# Altium schematic sheet DynamicComplexModulo_v1i1.SchDoc
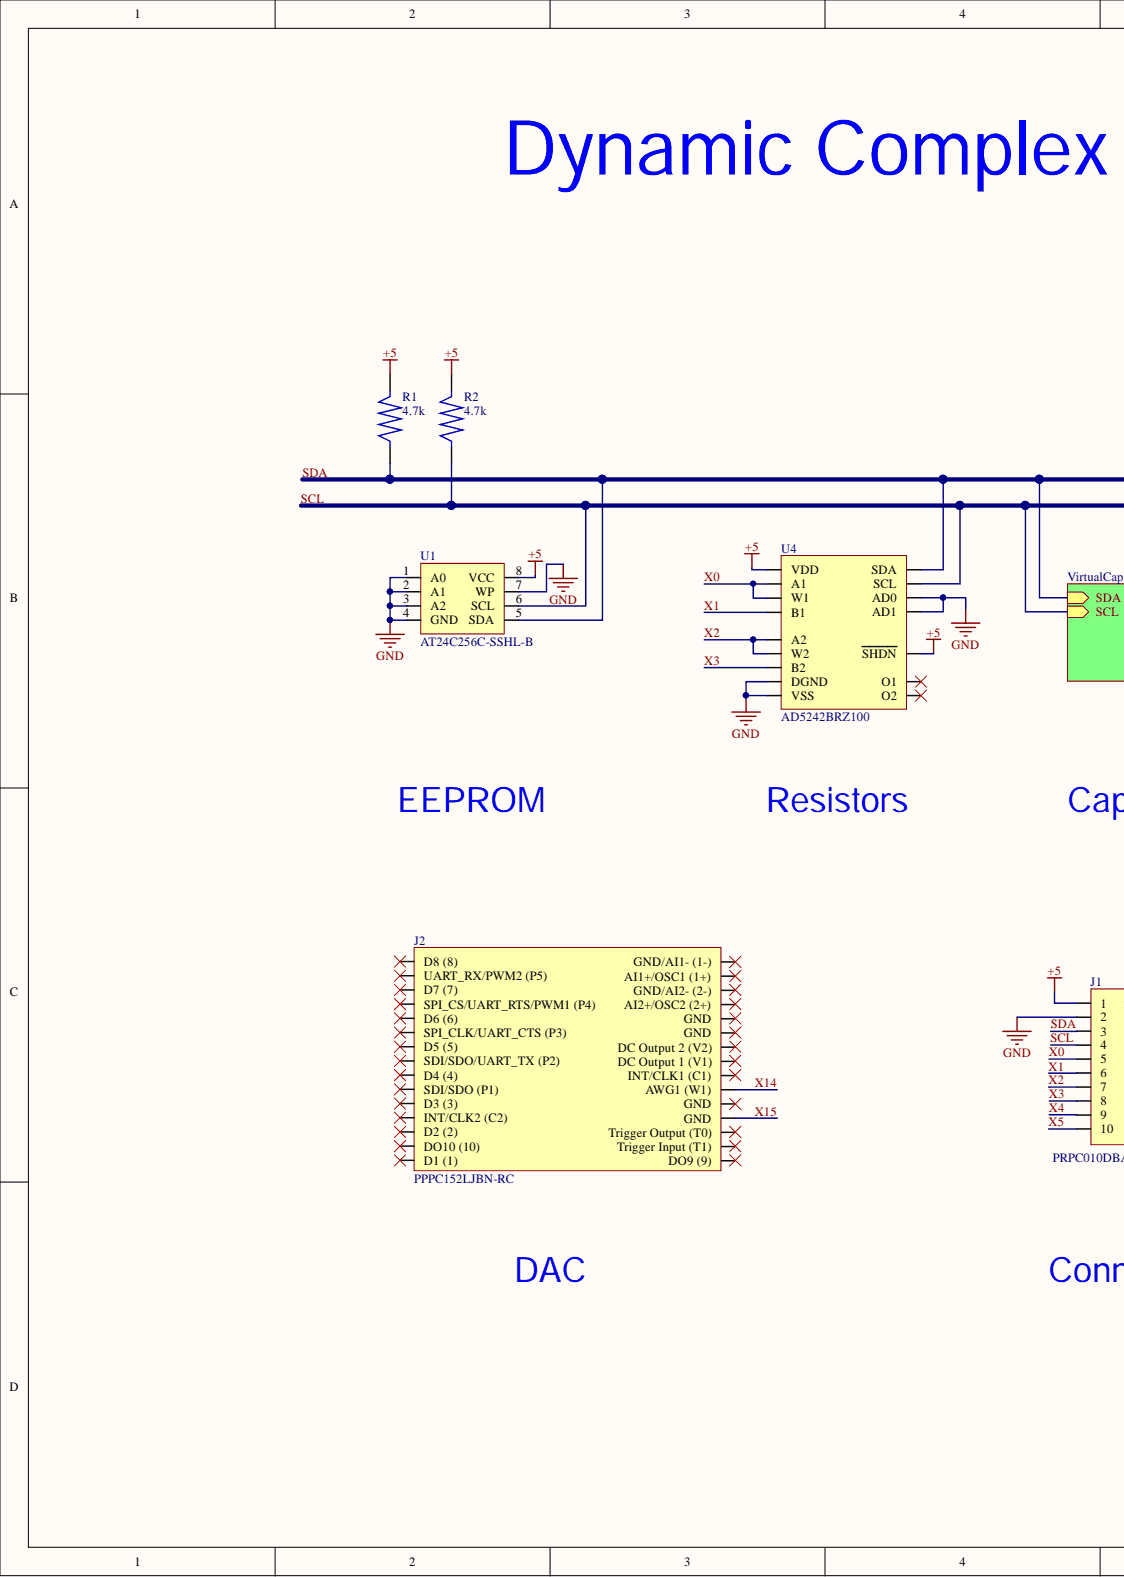
[diagram p0: DynamicComplexModulo_v1i1.SchDoc, left]
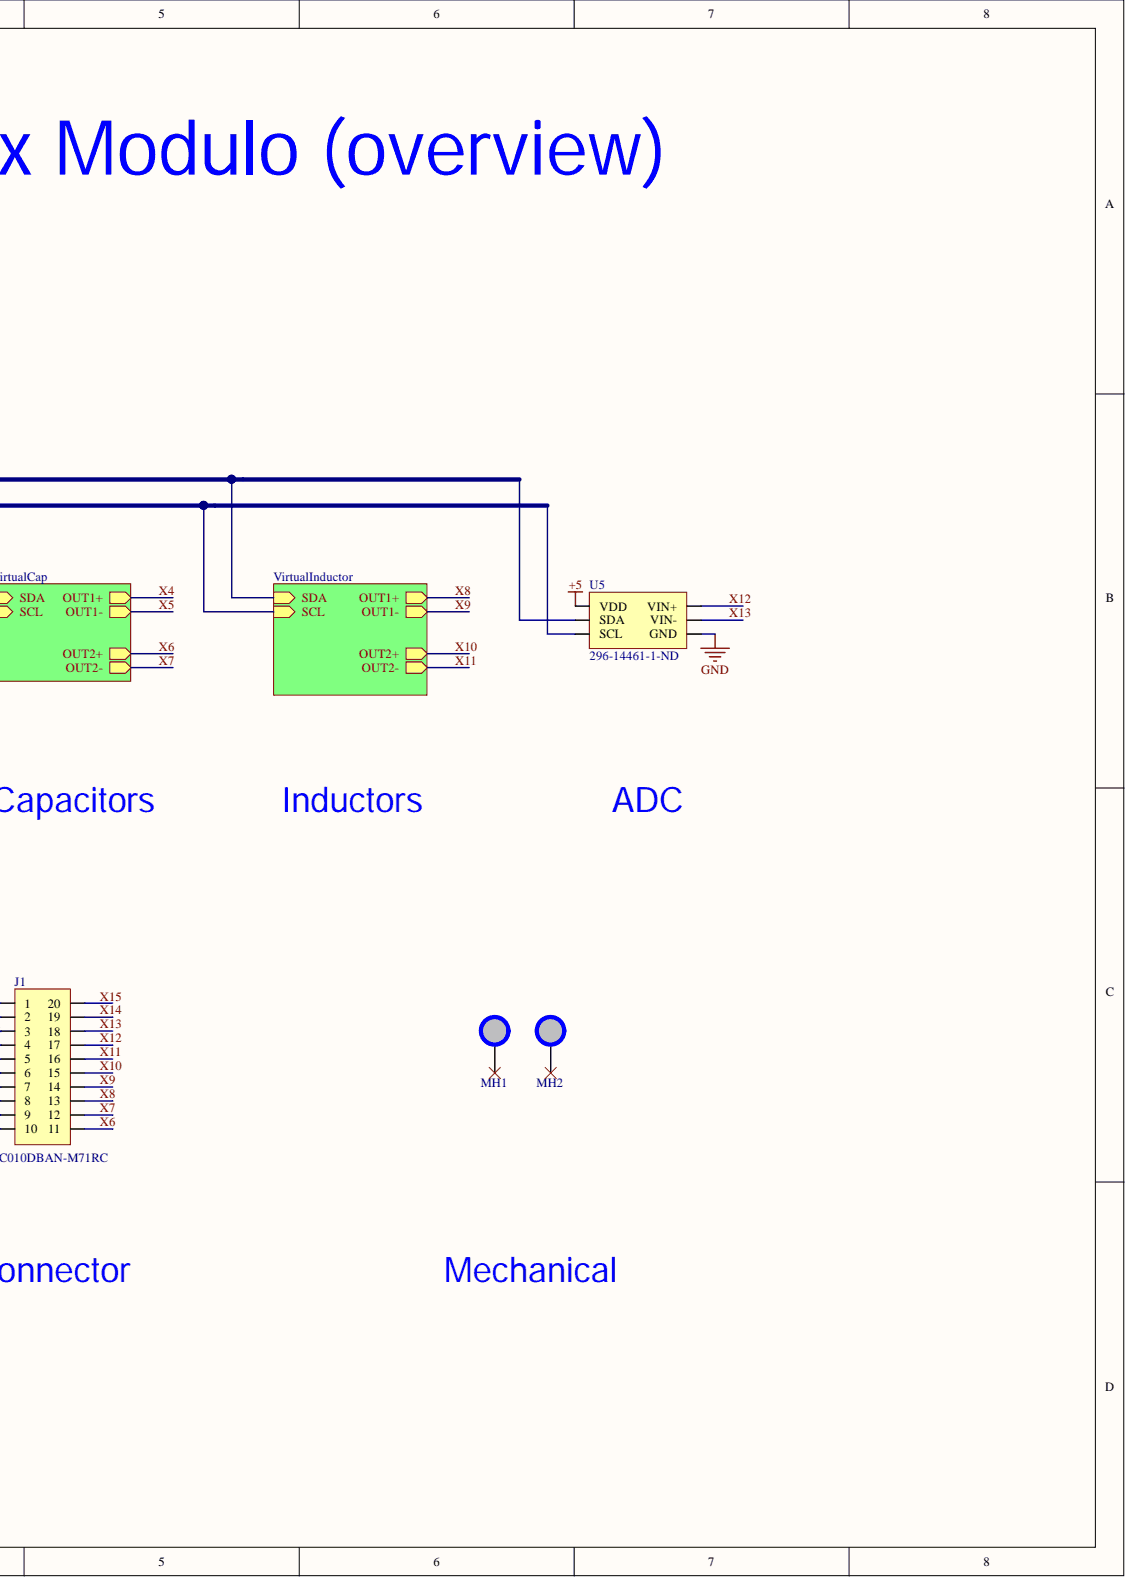
[diagram p1: DynamicComplexModulo_v1i1.SchDoc, right]

## Parts
J1  PRPC010DBAN-M71RC  [HDR_MALE_10x2x2.54]
J2  PPPC152LJBN-RC  [HDR_FEMALE_15x2x2.54]
MH1  MH 3.2mm NP  [MH3.2mm]  (value not drawn)  (no BOM)
MH2  MH 3.2mm NP  [MH3.2mm]  (value not drawn)  (no BOM)
R1  4.7k  [R0805]
R2  4.7k  [R0805]
U1  AT24C256C-SSHL-B  [AT24C256C-SSHL-B]
U4  AD5242BRZ100  [AD5242BRZ100]
U5  296-14461-1-ND  [SOT23-6]

## Nets
+5: J1.1 R1.2 R2.2 U1.8(VCC) U4.5(VDD) U4.6(SHDN) U5.5(VDD)
GND: J1.2 U1.1(A0) U1.2(A1) U1.3(A2) U1.4(GND) U1.7(WP) U4.9(AD0) U4.10(AD1) U4.11(DGND) U4.12(VSS) U5.2(GND)
SCL: J1.4 R2.1 U1.6(SCL) U4.7(SCL) U5.3(SCL)
SDA: J1.3 R1.1 U1.5(SDA) U4.8(SDA) U5.4(SDA)
X0: J1.5 U4.2(A1) U4.3(W1)
X1: J1.6 U4.4(B1)
X2: J1.7 U4.15(W2) U4.16(A2)
X3: J1.8 U4.14(B2)
X4: J1.9
X5: J1.10
X6: J1.11
X7: J1.12
X8: J1.13
X9: J1.14
X10: J1.15
X11: J1.16
X12: J1.17 U5.1(VIN+)
X13: J1.18 U5.6(VIN-)
X14: J1.19 J2.21(AWG1_(W1))
X15: J1.20 J2.19(GND)

Not connected: J2 1(D8_(8)) 2(UART_RX/PWM2_(P5)) 3(D7_(7)) 4 5(D6_(6)) 6(SPI_CLK/UART_CTS_(P3)) 7(D5_(5)) 8(SDI/SDO/UART_TX_(P2)) 9(D4_(4)) 10(SDI/SDO_(P1)) 11(D3_(3)) 12(INT/CLK2_(C2)) 13(D2_(2)) 14(DO10_(10)) 15(D1_(1)) 16(DO9_(9)) 17(Trigger_Input_(T1)) 18(Trigger_Output_(T0)) 20(GND) 22(INT/CLK1_(C1)) 23(DC_Output_1_(V1)) 24(DC_Output_2_(V2)) 25(GND) 26(GND) 27(AI2+/OSC2_(2+)) 28(GND/AI2-_(2-)) 29(AI1+/OSC1_(1+)) 30(GND/AI1-_(1-)); MH1 1; MH2 1; U4 1(O1) 13(O2)

## Library data of the parts
J1 = HDR Male 2x10_2.54: 20 Positions Header, Unshrouded, Breakaway Connector 0.100" (2.54mm) Through Hole, Right Angle Gold; Manufacturer: Sullins; Connector Type: Male Pin; Number of Positions: 20; Pitch: 0.1inch; Colour: Black; Component Kind: Standard; ComponentLink1Description: https://datasheet.ciiva.com/741/xrxczzzdxxn-m71rc-11639-b-741675.pdf; ComponentLink1URL: https://datasheet.ciiva.com/741/xrxczzzdxxn-m71rc-11639-b-741675.pdf; Contact Mating Length: 0.23inch; Contact Plating: Gold; Manufacturer Part Number: PRPC010DBAN-M71RC; Mount: Through Hole; Number of Rows: 2; Package Quantity: 1; Packaging: Bulk; Pricing 1: 1=1.08, 10=0.97, 100=0.7989, 500=0.6315, 1000=0.5421, 5000=0.49455, 10000=0.47553 (USD); Row Spacing: 0.1inch; Stock 1: 459; Supplier 1: Digi-Key; Supplier Part Number 1: S2111EC-10-ND; System: Connector; Termination: Solder; Library Name: DynamicComplexModulo_v1i1.SchLib
J2 = OPENSCOPE_CONNECTOR: CONN FMALE 30POS DL .1" R/A GOLD; Manufacturer: Sullins; Connector Type: Socket,Header; Number of Positions: 30; Pitch: 0.1inch; Colour: Black; Component Kind: Standard; ComponentLink1Description: http://sullinscorp.com/drawings/101_P(N)PxCxx2LJBN-RC,_10494-H.pdf; ComponentLink1URL: http://sullinscorp.com/drawings/101_P(N)PxCxx2LJBN-RC,_10494-H.pdf; Contact Material: Bronze; Contact Plating: Gold; Height: 5mm; Housing Colour: Black; Housing Material: Plastic; Lead Free: Lead Free; Length: 38.6mm; Manufacturer Part Number: PPPC152LJBN-RC; Mount: Through Hole; Number of Rows: 2; Package Quantity: 1000; Packaging: Bulk; Pricing 1: 1=2.22, 10=2.018, 100=1.7294, 500=1.44112, 1000=1.23525, 5000=1.1895 (USD); Row Spacing: 0.1inch; Stock 1: 2029; Supplier 1: Digi-Key; Supplier Part Number 1: S5568-ND; Termination: Solder; Width: 8.5mm; Library Name: DynamicComplexModulo_v1i1.SchLib
MH1 MH2 = MHNP: Mounting hole 3.2mm non plated; Library Name: DynamicComplexModulo_v1i1.SchLib
R1 R2 = R0805_4.7k: Component Kind: Standard; Description: 4.7k; Library Name: DynamicComplexModulo_v1i1.SchLib
U1 = AT24C256C-SSHL-B: ATMEL         AT24C256C-SSHL-B            EEPROM, 256 Kbit, 32K x 8bit, 1 MHz, Serial, SOIC, 8 Pins; Manufacturer: Microchip / Atmel; Access Time: 550ns; Density: 256Kb; Frequency: 1MHz; Interface: I2C,Serial,2-Wire; Max Supply Voltage: 5.5V; Min Supply Voltage: 1.7V; Nominal Supply Current: 3mA; Number of Words: 32768; Voltage: 5V; Case/Package: SOIC; Component Kind: Standard; ComponentLink1Description: https://datasheet.ciiva.com/15123/getdatasheetpartid-367456-15123424.pdf; ComponentLink1URL: https://datasheet.ciiva.com/15123/getdatasheetpartid-367456-15123424.pdf; ComponentLink2Description: https://datasheet.ciiva.com/15123/getdatasheetpartid-304516-15123348.pdf; ComponentLink2URL: https://datasheet.ciiva.com/15123/getdatasheetpartid-304516-15123348.pdf; ComponentLink3Description: https://datasheet.ciiva.com/1910/atmel-8568-seeprom-at24c256c-datasheet-1910422.pdf; ComponentLink3URL: https://datasheet.ciiva.com/1910/atmel-8568-seeprom-at24c256c-datasheet-1910422.pdf; ComponentLink4Description: https://datasheet.ciiva.com/1509/1726561-1509879.pdf; ComponentLink4URL: https://datasheet.ciiva.com/1509/1726561-1509879.pdf; Contact Plating: Tin,Matte; Height: 1.5mm; Length: 5.05mm; Manufacturer Part Number: AT24C256C-SSHL-B; Max Frequency: 1MHz; Max Operating Temperature: 85°C; Memory Size: 256Kb; Memory Type: EEPROM; Min Operating Temperature: -40°C; Mount: Surface Mount; Number of Pins: 8; Package Quantity: 100; Packaging: Bulk; Pricing 1: 1=0.68, 10=0.619, 25=0.6108, 100=0.543 (USD); Radiation Hardening: No; REACH SVHC: No SVHC; Stock 1: 4655; Supplier 1: Digi-Key; Supplier Part Number 1: AT24C256C-SSHL-B-ND; Width: 3.99mm; Library Name: DynamicComplexModulo_v1i1.SchLib
U4 = AD5242BRZ100-REEL7: IC POT DGTL DUAL 256POS 16SOIC; Manufacturer: Analog Devices; -3db Bandwidth: 650kHz; Dual Supply Voltage: 2.5V; Frequency: 400kHz; Interface: I2C; Max Dual Supply Voltage: 2.7V; Max Supply Current: 100nA; Max Supply Voltage: 5.5V; Memory Type: Volatile; Min Dual Supply Voltage: 2.3V; Number of Circuits: 2; Number of Positions: 256; Resistance: 100kR; Temperature Coefficient: 30ppm/°C; Tolerance: 50%; Case/Package: SOIC; Component Kind: Standard; ComponentLink1Description: https://datasheet.ciiva.com/3046/ad5241-5242-3046354.pdf; ComponentLink1URL: https://datasheet.ciiva.com/3046/ad5241-5242-3046354.pdf; ComponentLink2Description: https://datasheet.ciiva.com/1339/ad5241-5242-1339036.pdf; ComponentLink2URL: https://datasheet.ciiva.com/1339/ad5241-5242-1339036.pdf; Contact Plating: Tin,Matte; Lead Free: Contains Lead; Manufacturer Part Number: AD5242BRZ100-REEL7; Max Operating Temperature: 105°C; Min Operating Temperature: -40°C; Min Supply Voltage: 2.7V; Mount: Surface Mount; Nominal Supply Current: 100nA; Number of Elements: 2; Number of Pins: 16; Number of Taps: 256; Operating Supply Current: 100nA; Package Quantity: 1000; Packaging: Tape and Reel; Quiescent Current: 100nA; Stock 1: 881; Supplier 1: Digi-Key; Taper: Linear; Library Name: DynamicComplexModulo_v1i1.SchLib
U5 = ADS1110A7IDBVT: IC ADC 16-BIT I2C PROGBL SOT23-6; Manufacturer: Texas Instruments; Conversion Rate: 128S/s; Differential Nonlinearity: 1LSB; Max Power Dissipation: 1.75mW; Max Supply Voltage: 5.5V; Min Supply Voltage: 2.7V; Nominal Supply Current: 240uA; Number of A/D Converters: 1; Number of Bits: 16; Operating Supply Voltage: 5V; Polarity: Bipolar,Unipolar; Resolution: 16b; Sampling Rate: 0.128KS/s; Supply Type: Single; Case/Package: SOT-23-6; Component Kind: Standard; ComponentLink1Description: https://datasheet.ciiva.com/25993/ads1110-25993414.pdf; ComponentLink1URL: https://datasheet.ciiva.com/25993/ads1110-25993414.pdf; ComponentLink2Description: https://datasheet.ciiva.com/1262/ads1110-1262313.pdf; ComponentLink2URL: https://datasheet.ciiva.com/1262/ads1110-1262313.pdf; ComponentLink3Description: https://datasheet.ciiva.com/1262/ads1110-1262314.pdf; ComponentLink3URL: https://datasheet.ciiva.com/1262/ads1110-1262314.pdf; ComponentLink4Description: https://datasheet.ciiva.com/1262/ads1110-1262315.pdf; ComponentLink4URL: https://datasheet.ciiva.com/1262/ads1110-1262315.pdf; ComponentLink5Description: https://datasheet.ciiva.com/14260/sbas276a-14260046.pdf; ComponentLink5URL: https://datasheet.ciiva.com/14260/sbas276a-14260046.pdf; ComponentLink6Description: https://datasheet.ciiva.com/26800/ads1110a7idbvt-26800806.pdf; ComponentLink6URL: https://datasheet.ciiva.com/26800/ads1110a7idbvt-26800806.pdf; ComponentLink7Description: https://datasheet.ciiva.com/41461/001186690-da-01-en-ic-adc-16-bi-ads1110a7idbvt-sot-23-6-tid-41461014.pdf; ComponentLink7URL: https://datasheet.ciiva.com/41461/001186690-da-01-en-ic-adc-16-bi-ads1110a7idbvt-sot-23-6-tid-41461014.pdf; Contact Plating: Gold; Interface: I2C; Lead Free: Lead Free; Manufacturer Part Number: ADS1110A7IDBVT; Max Operating Temperature: 125°C; Min Operating Temperature: -40°C; Mount: Surface Mount; Number of Analog Inputs: 1; Number of Channels: 1; Number of Converters: 1; Number of Elements: 1; Number of Inputs: 1; Number of Pins: 6; Package Quantity: 250; Packaging: Tape and Reel; Power Dissipation: 1.75mW; Pricing 1: 1=6.46, 10=5.805, 100=4.7561 (USD); Radiation Hardening: No; Stock 1: 469; Supplier 1: Digi-Key; Supplier Part Number 1: 296-14468-6-ND; Library Name: DynamicComplexModulo_v1i1.SchLib
